# Altium schematic sheet connectors.SchDoc
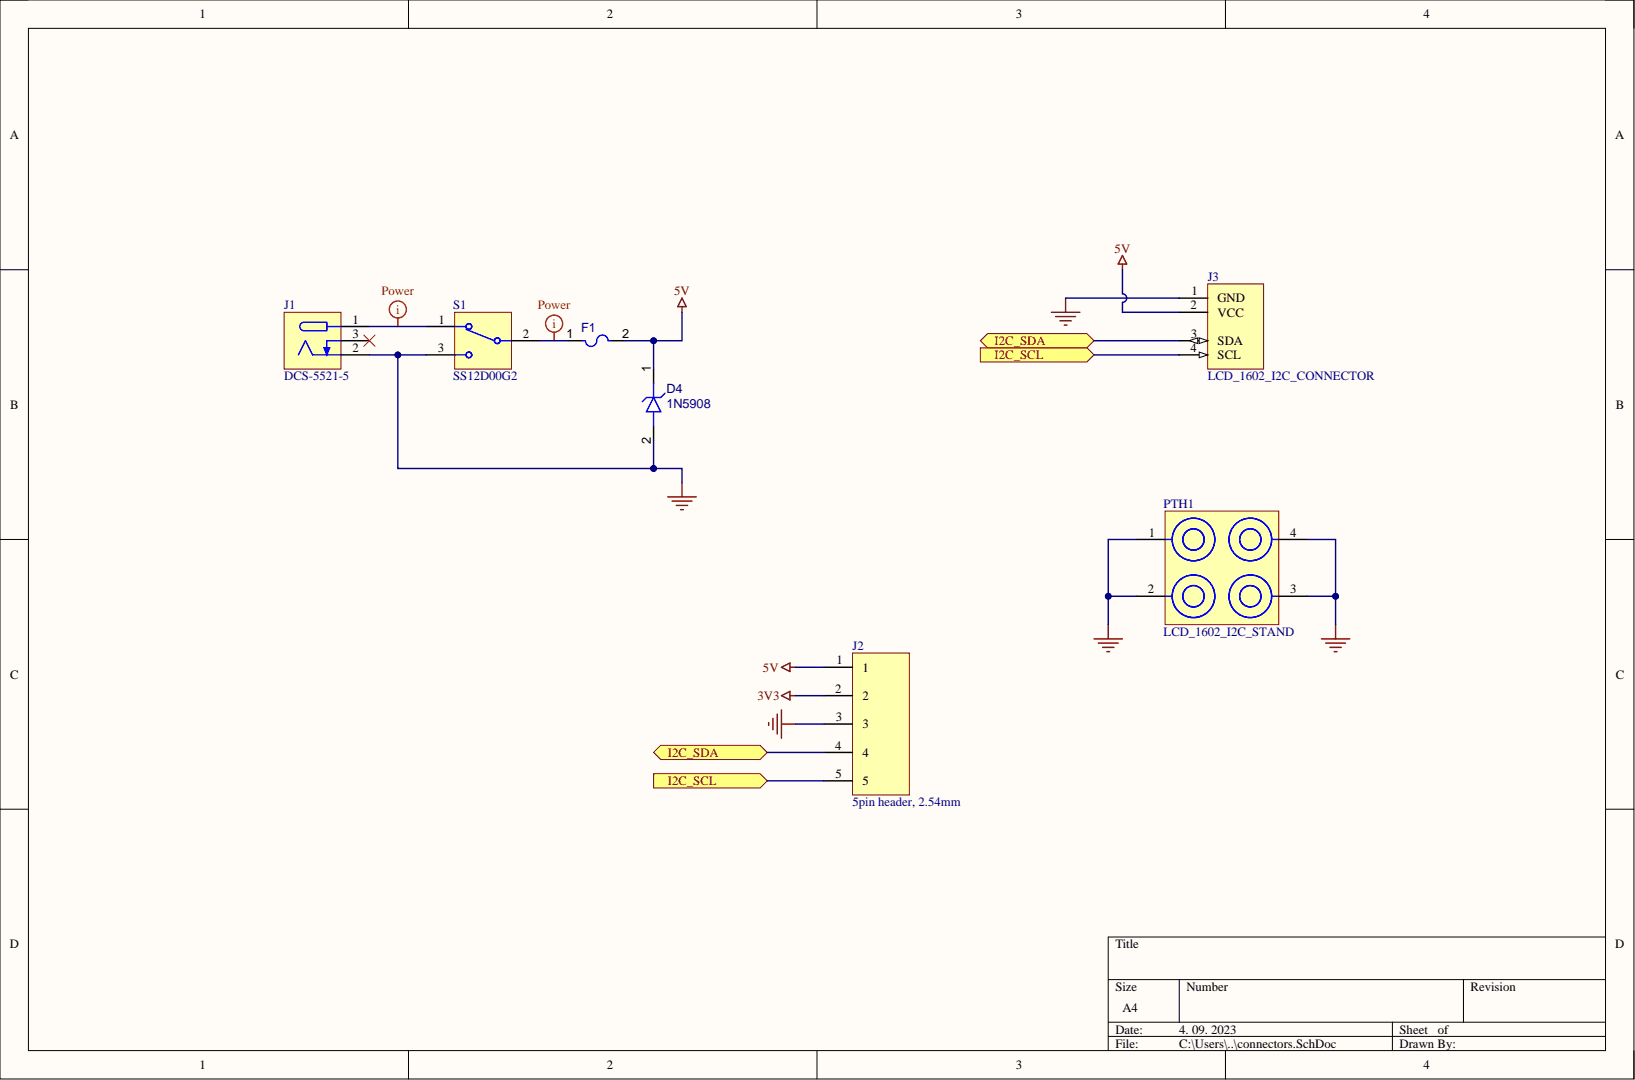
[diagram p0: connectors.SchDoc]

## Parts
D4  1N5908  [Transil Diode]
F1  POLYFUSE BU110  [Radial Leaded PTC]  (value not drawn)
J1  DCS-5521-5  [DCS-5521-5-Footprint-1]
J2  5pin header, 2.54mm  [5PIN_HEADER]
J3  LCD_1602_I2C_CONNECTOR  [LCD_1602_I2C_CONNECTOR-Footprint-1]
PTH1  LCD_1602_I2C_STAND  [LCD_1602_I2C_CON]
S1  SS12D00G2  [SS12D00G2-Footprint-1]

## Ports
I2C_SCL, I2C_SDA

## Nets
3V3: J2.2
5V: D4.1 F1.2 J2.1 J3.2(VCC)
GND: D4.2 J1.2 J2.3 J3.1(GND) PTH1.1 PTH1.2 PTH1.3 PTH1.4 S1.3
I2C_SCL: J2.5 J3.4(SCL) ; port I2C_SCL
I2C_SDA: J2.4 J3.3(SDA) ; port I2C_SDA
NetF1_1: F1.1 S1.2
NetJ1_1: J1.1 S1.1

Not connected: J1 3

## Library data of the parts
D4 = CMP-004-00033-1: 1N5908 Series 1500 W 6 V Uni Directional TVS Diode - DO-201; Max Surge Current: 120A; Case/Package: DO-201; Component Kind: Standard; Max Operating Temperature: 175°C; Min Operating Temperature: -55°C; Mounting Technology: Through Hole; Pins: 2; Library Name: Budapest University of Technology and Economics
F1 = CMP-005-00014-1: Radial leaded PTC, 700mW, 1.1A, THT; Component Kind: Standard; Current Rating: 1.1A; Max Operating Temperature: 85°C; Min Operating Temperature: -45°C; Mounting Technology: THT; Pins: 2; Library Name: Budapest University of Technology and Economics
J1 = CMP-002-00072-2: DC source connector, round; Component Kind: Standard; Pins: 3; Library Name: Budapest University of Technology and Economics
J2 = CMP-002-00073-1: Component Kind: Standard; Description: 5pin header, 2.54mm; Pins: 5; Library Name: Budapest University of Technology and Economics
J3 = CMP-00030-00003-2: LCD module with I2C, signal connector part; Component Kind: Standard; Pins: 4; Library Name: Budapest University of Technology and Economics
PTH1 = CMP-002-00071-4: LCD module with I2C communication interface, stand part; Component Kind: Standard; Pins: 4; Library Name: Budapest University of Technology and Economics
S1 = CMP-011-00013-1: Two state slide switch, ON-ON, SPDT; Component Kind: Standard; Mounting Technology: Through Hole; Pins: 3; Library Name: Budapest University of Technology and Economics
